# Altium schematic sheet FOC_4.SchDoc
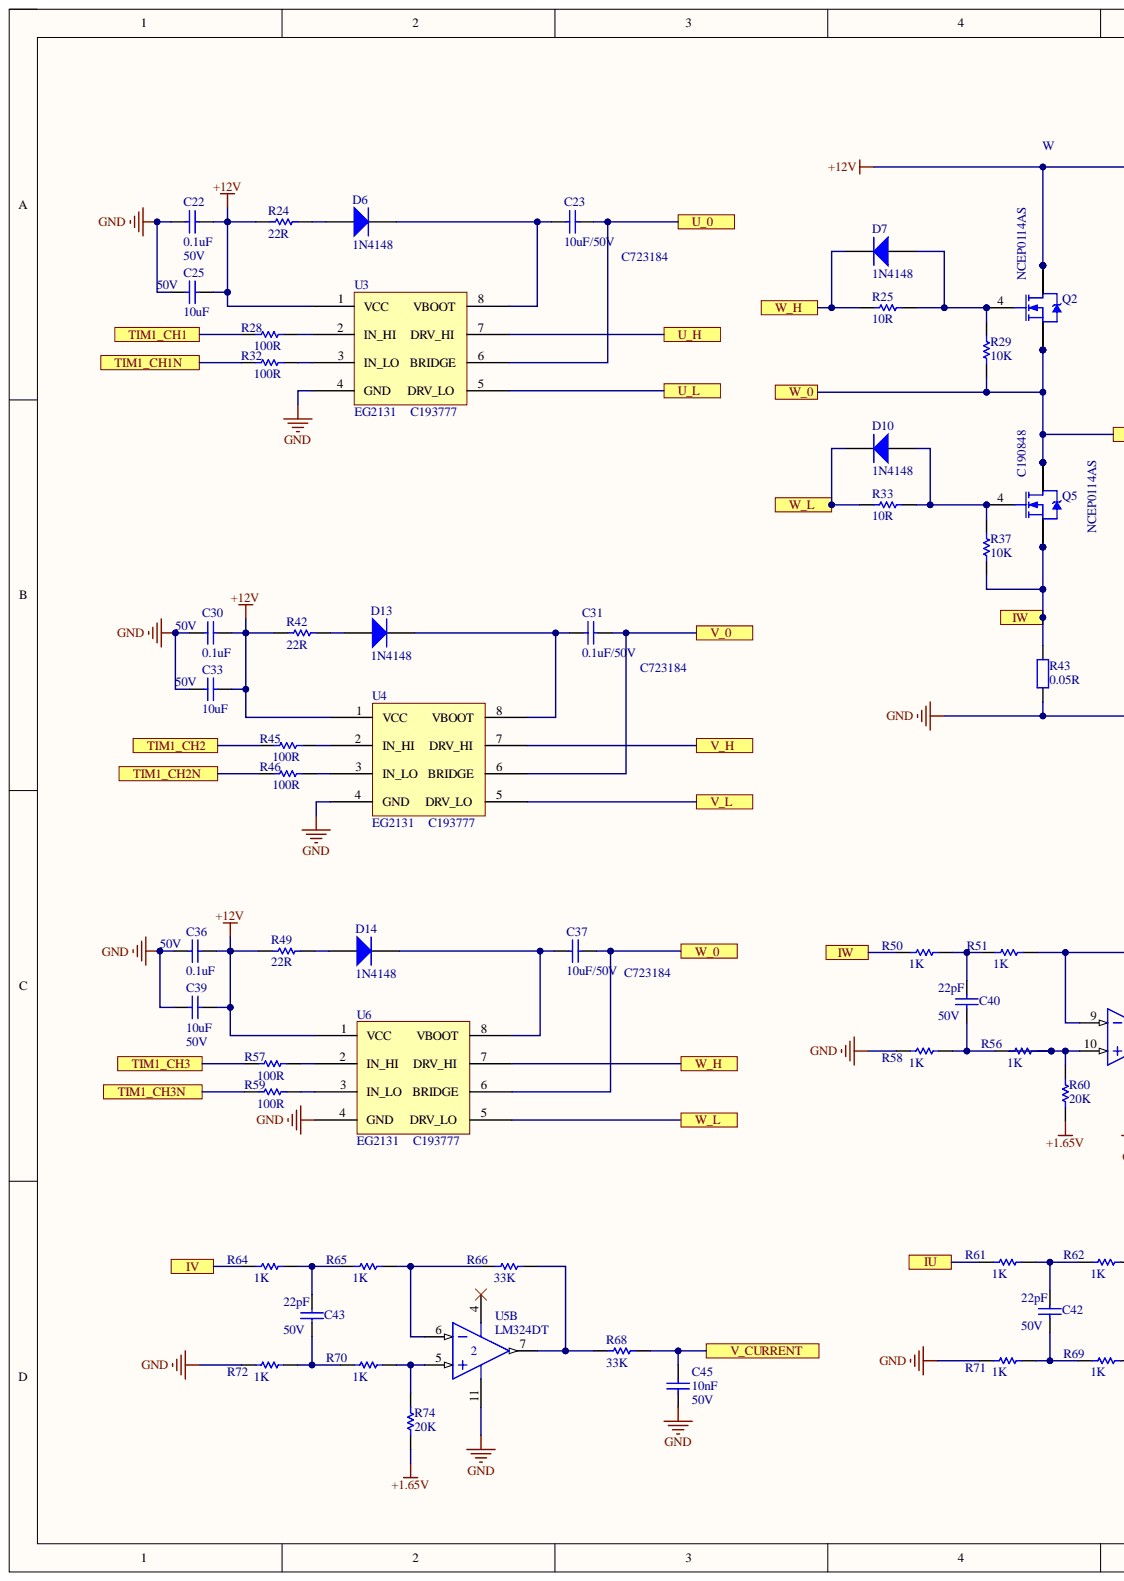
[diagram p0: FOC_4.SchDoc, left]
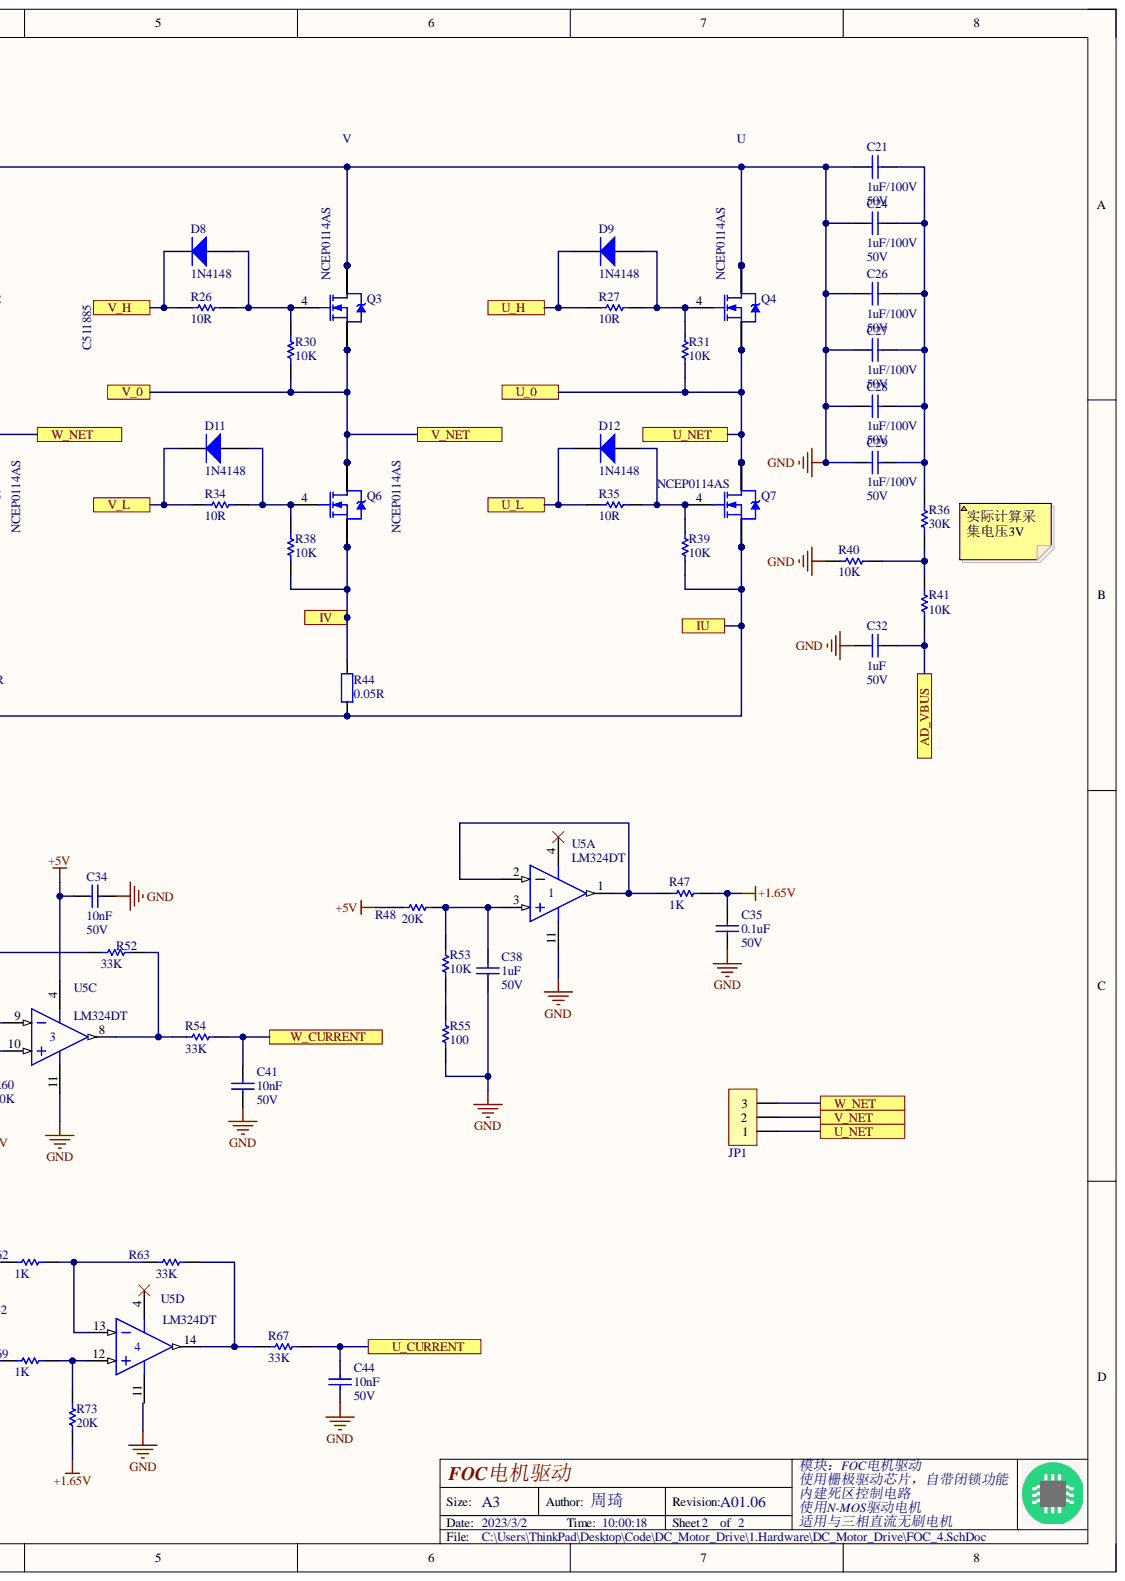
[diagram p1: FOC_4.SchDoc, right]

## Parts
C21  1uF/100V  [C 1210]
C22  0.1uF  [C 0603]
C23  10uF/50V  [C 0603]
C24  1uF/100V  parm: 50V  [C 1210]
C25  10uF  parm: 50V  [C 0805]
C26  1uF/100V  parm: 50V  [C 1210]
C27  1uF/100V  parm: 50V  [C 1210]
C28  1uF/100V  parm: 50V  [C 1210]
C29  1uF/100V  parm: 50V  [C 1210]
C30  0.1uF  parm: 50V  [C 0603]
C31  0.1uF/50V  [C 0603]
C32  1uF  parm: 50V  [C 0603]
C33  10uF  parm: 50V  [C 0805]
C34  10nF  [C 0603]
C35  0.1uF  parm: 50V  [C 0603]
C36  0.1uF  parm: 50V  [C 0603]
C37  10uF/50V  [C 0603]
C38  1uF  parm: 50V  [C 0603]
C39  10uF  parm: 50V  [C 0805]
C40  22pF  parm: 50V  [C 0603]
C41  10nF  parm: 50V  [C 0603]
C42  22pF  parm: 50V  [C 0603]
C43  22pF  parm: 50V  [C 0603]
C44  10nF  parm: 50V  [C 0603]
C45  10nF  parm: 50V  [C 0603]
D6  1N4148  [SOD123]
D7  1N4148  [SOD123]
D8  1N4148  [SOD123]
D9  1N4148  [SOD123]
D10  1N4148  [SOD123]
D11  1N4148  [SOD123]
D12  1N4148  [SOD123]
D13  1N4148  [SOD123]
D14  1N4148  [SOD123]
JP1  HDR-1X3  [KF3P]  (value not drawn)
Q2  NCEP0114AS  [SOP8]
Q3  NCEP0114AS  [SOP8]
Q4  NCEP0114AS  [SOP8]
Q5  NCEP0114AS  [SOP8]
Q6  NCEP0114AS  [SOP8]
Q7  NCEP0114AS  [SOP8]
R24  22R  [R 0603]
R25  10R  [R 0603]
R26  10R  [R 0603]
R27  10R  [R 0603]
R28  100R  [R 0603]
R29  10K  [R 0603]
R30  10K  [R 0603]
R31  10K  [R 0603]
R32  100R  [R 0603]
R33  10R  [R 0603]
R34  10R  [R 0603]
R35  10R  [R 0603]
R36  30K  [R 0603]
R37  10K  [R 0603]
R38  10K  [R 0603]
R39  10K  [R 0603]
R40  10K  [R 0603]
R41  10K  [R 0603]
R42  22R  [R 0603]
R43  0.05R  [R 2512-HP]
R44  0.05R  [R 2512-HP]
R45  100R  [R 0603]
R46  100R  [R 0603]
R47  1K  [R 0603]
R48  20K  [R 0603]
R49  22R  [R 0603]
R50  1K  [R 0603]
R51  1K  [R 0603]
R52  33K  [R 0603]
R53  10K  [R 0603]
R54  33K  [R 0603]
R55  100  [R 0603]
R56  1K  [R 0603]
R57  100R  [R 0603]
R58  1K  [R 0603]
R59  100R  [R 0603]
R60  20K  [R 0603]
R61  1K  [R 0603]
R62  1K  [R 0603]
R63  33K  [R 0603]
R64  1K  [R 0603]
R65  1K  [R 0603]
R66  33K  [R 0603]
R67  33K  [R 0603]
R68  33K  [R 0603]
R69  1K  [R 0603]
R70  1K  [R 0603]
R71  1K  [R 0603]
R72  1K  [R 0603]
R73  20K  [R 0603]
R74  20K  [R 0603]
U3  EG2131  [SOP8]
U4  EG2131  [SOP8]
U5  LM324DT  [SOIC14]  (parts A,B,C,D)
U6  EG2131  [SOP8]

## Ports
AD_VBUS, IU, IV, IW, TIM1_CH1, TIM1_CH1N, TIM1_CH2, TIM1_CH2N, TIM1_CH3, TIM1_CH3N, U_0, U_CURRENT, U_H, U_L, U_NET, V_0, V_CURRENT, V_H, V_L, V_NET, W_0, W_CURRENT, W_H, W_L, W_NET

## Nets
+1.65V: C35.2 R47.1 R60.1 R73.1 R74.1
+5V: C34.2 R48.1 U5.4
+12V: C21.2 C22.1 C22.2 C24.2 C25.1 C25.2 C26.2 C27.2 C28.2 C29.2 C30.1 C30.2 C32.1 C33.1 C33.2 C34.1 C35.1 C36.1 C36.2 C38.1 C39.1 C39.2 C41.1 C44.1 C45.1 Q2.5 Q2.6 Q2.7 Q2.8 Q3.5 Q3.6 Q3.7 Q3.8 Q4.5 Q4.6 Q4.7 Q4.8 Q7.1 Q7.2 Q7.3 R24.1 R39.1 R40.2 R42.1 R43.1 R44.1 R49.1 R55.1 R58.1 R61.1 R71.1 R72.1 U3.1(VCC) U3.4(GND) U4.1(VCC) U4.4(GND) U5.11 U6.1(VCC) U6.4(GND) ; port IU
AD_VBUS: C32.2 R41.2 ; port AD_VBUS
IV: Q6.1 Q6.2 Q6.3 R38.1 R44.2 R64.1 ; port IV
IW: Q5.1 Q5.2 Q5.3 R37.1 R43.2 R50.1 ; port IW
TIM1_CH1: R28.1 ; port TIM1_CH1
TIM1_CH1N: R32.1 ; port TIM1_CH1N
TIM1_CH2: R45.1 ; port TIM1_CH2
TIM1_CH2N: R46.1 ; port TIM1_CH2N
TIM1_CH3: R57.1 ; port TIM1_CH3
TIM1_CH3N: R59.1 ; port TIM1_CH3N
U_0: C23.1 JP1.1 Q4.1 Q4.2 Q4.3 Q7.5 Q7.6 Q7.7 Q7.8 R31.1 U3.6(BRIDGE) ; port U_0, port U_NET
U_CURRENT: C44.2 R67.1 ; port U_CURRENT
U_H: D9.2 R27.1 U3.7(DRV_HI) ; port U_H
U_L: D12.2 R35.1 U3.5(DRV_LO) ; port U_L
V_0: C31.1 JP1.2 Q3.1 Q3.2 Q3.3 Q6.5 Q6.6 Q6.7 Q6.8 R30.1 U4.6(BRIDGE) ; port V_0, port V_NET
V_CURRENT: C45.2 R68.1 ; port V_CURRENT
V_H: D8.2 R26.1 U4.7(DRV_HI) ; port V_H
V_L: D11.2 R34.1 U4.5(DRV_LO) ; port V_L
W_0: C37.1 JP1.3 Q2.1 Q2.2 Q2.3 Q5.5 Q5.6 Q5.7 Q5.8 R29.1 U6.6(BRIDGE) ; port W_0, port W_NET
W_CURRENT: C41.2 R54.1 ; port W_CURRENT
W_H: D7.2 R25.1 U6.7(DRV_HI) ; port W_H
W_L: D10.2 R33.1 U6.5(DRV_LO) ; port W_L
NetC21_1: C21.1 C24.1 C26.1 C27.1 C28.1 C29.1 R36.2
NetC23_2: C23.2 D6.2 U3.8(VBOOT)
NetC31_2: C31.2 D13.2 U4.8(VBOOT)
NetC37_2: C37.2 D14.2 U6.8(VBOOT)
NetC38_2: C38.2 R48.2 R53.2 U5.3
NetC40_1: C40.1 R50.2 R51.1
NetC40_2: C40.2 R56.1 R58.2
NetC42_1: C42.1 R61.2 R62.1
NetC42_2: C42.2 R69.1 R71.2
NetC43_1: C43.1 R64.2 R65.1
NetC43_2: C43.2 R70.1 R72.2
NetD6_1: D6.1 R24.2
NetD7_1: D7.1 Q2.4 R25.2 R29.2
NetD8_1: D8.1 Q3.4 R26.2 R30.2
NetD9_1: D9.1 Q4.4 R27.2 R31.2
NetD10_1: D10.1 Q5.4 R33.2 R37.2
NetD11_1: D11.1 Q6.4 R34.2 R38.2
NetD12_1: D12.1 Q7.4 R35.2 R39.2
NetD13_1: D13.1 R42.2
NetD14_1: D14.1 R49.2
NetR28_2: R28.2 U3.2(IN_HI)
NetR32_2: R32.2 U3.3(IN_LO)
NetR36_1: R36.1 R40.1 R41.1
NetR45_2: R45.2 U4.2(IN_HI)
NetR46_2: R46.2 U4.3(IN_LO)
NetR47_2: R47.2 U5.1 U5.2
NetR51_2: R51.2 R52.1 U5.9
NetR52_2: R52.2 R54.2 U5.8
NetR53_1: R53.1 R55.2
NetR56_2: R56.2 R60.2 U5.10
NetR57_2: R57.2 U6.2(IN_HI)
NetR59_2: R59.2 U6.3(IN_LO)
NetR62_2: R62.2 R63.1 U5.13
NetR63_2: R63.2 R67.2 U5.14
NetR65_2: R65.2 R66.1 U5.6
NetR66_2: R66.2 R68.2 U5.7
NetR69_2: R69.2 R73.2 U5.12
NetR70_2: R70.2 R74.2 U5.5

## Library data of the parts
C21 C22 C34 = Cap: LC: C1591; parm: 50V; Component Kind: Standard; Library Name: Miscellaneous Devices.IntLib
C23 C31 C37 = Cap: C89632; LC: C1591; parm: 100V; Component Kind: Standard; Library Name: Miscellaneous Devices.IntLib
C24-C30 C32 C33 C35 C36 C38-C45 = Cap: LC: C1591; Component Kind: Standard; Library Name: Miscellaneous Devices.IntLib
D6-D14 = SOD-323 1N4148WS T4: 二极管; Component Kind: Standard; ComponentLink1Description: 供应商链接; ComponentLink1URL: http://www.szlcsc.com/product/details_2485.html; Library Name: Miscellaneous Devices LC.IntLib; Package: SOD-323; Supplier: LC; SuppliersPartNumber: C2128
JP1 = Header 3: 3P接插件; 版权归属: 源创客; BOM名称: 3P接插件; Component Kind: Standard; Library Name: 接插件.SchLib; 学习资源: www.ooocke.com
Q2-Q7 = NMOS-2: C190848; Note: Pin 2 is electrically connected to pin 4.; Code_JEDEC: TO-220-AB; Component Kind: Standard; ComponentLink1Description: Manufacturer Link; ComponentLink1URL: http://www.irf.com/; DatasheetDocument: PD-9.814; LatestRevisionDate: 17-Jul-2002; LatestRevisionNote: Re-released for DXP Platform.; Library Name: Miscellaneous Devices.IntLib; PackageReference: TO-220AB; Published: 8-Jun-2000; Publisher: 60V
R24-R42 R45-R74 = Res1: Resistor; Component Kind: Standard; Library Name: Miscellaneous Devices.IntLib
R43 R44 = R-I: 电流检测电阻; 版权归属: 源创客; BOM名称: 电流检测电阻; Component Kind: Standard; Library Name: 电阻-电容-电感.SchLib; 学习资源: www.ooocke.com
U3 U4 U6 = NCP5106BDR2G: C193777; Component Kind: Standard; 编号: C193777; Library Name: Tang.SchLib
U5 = LM324DT_[C71035]: 运放; Component Kind: Standard; ComponentLink1Description: 供应商链接; ComponentLink1URL: http://www.szlcsc.com/product/details_72134.html; Library Name: Miscellaneous Devices LC.IntLib; Package: SOIC-14_150mil; Supplier: LC; SuppliersPartNumber: C71035
